# Altium schematic sheet TestingPCB.SchDoc
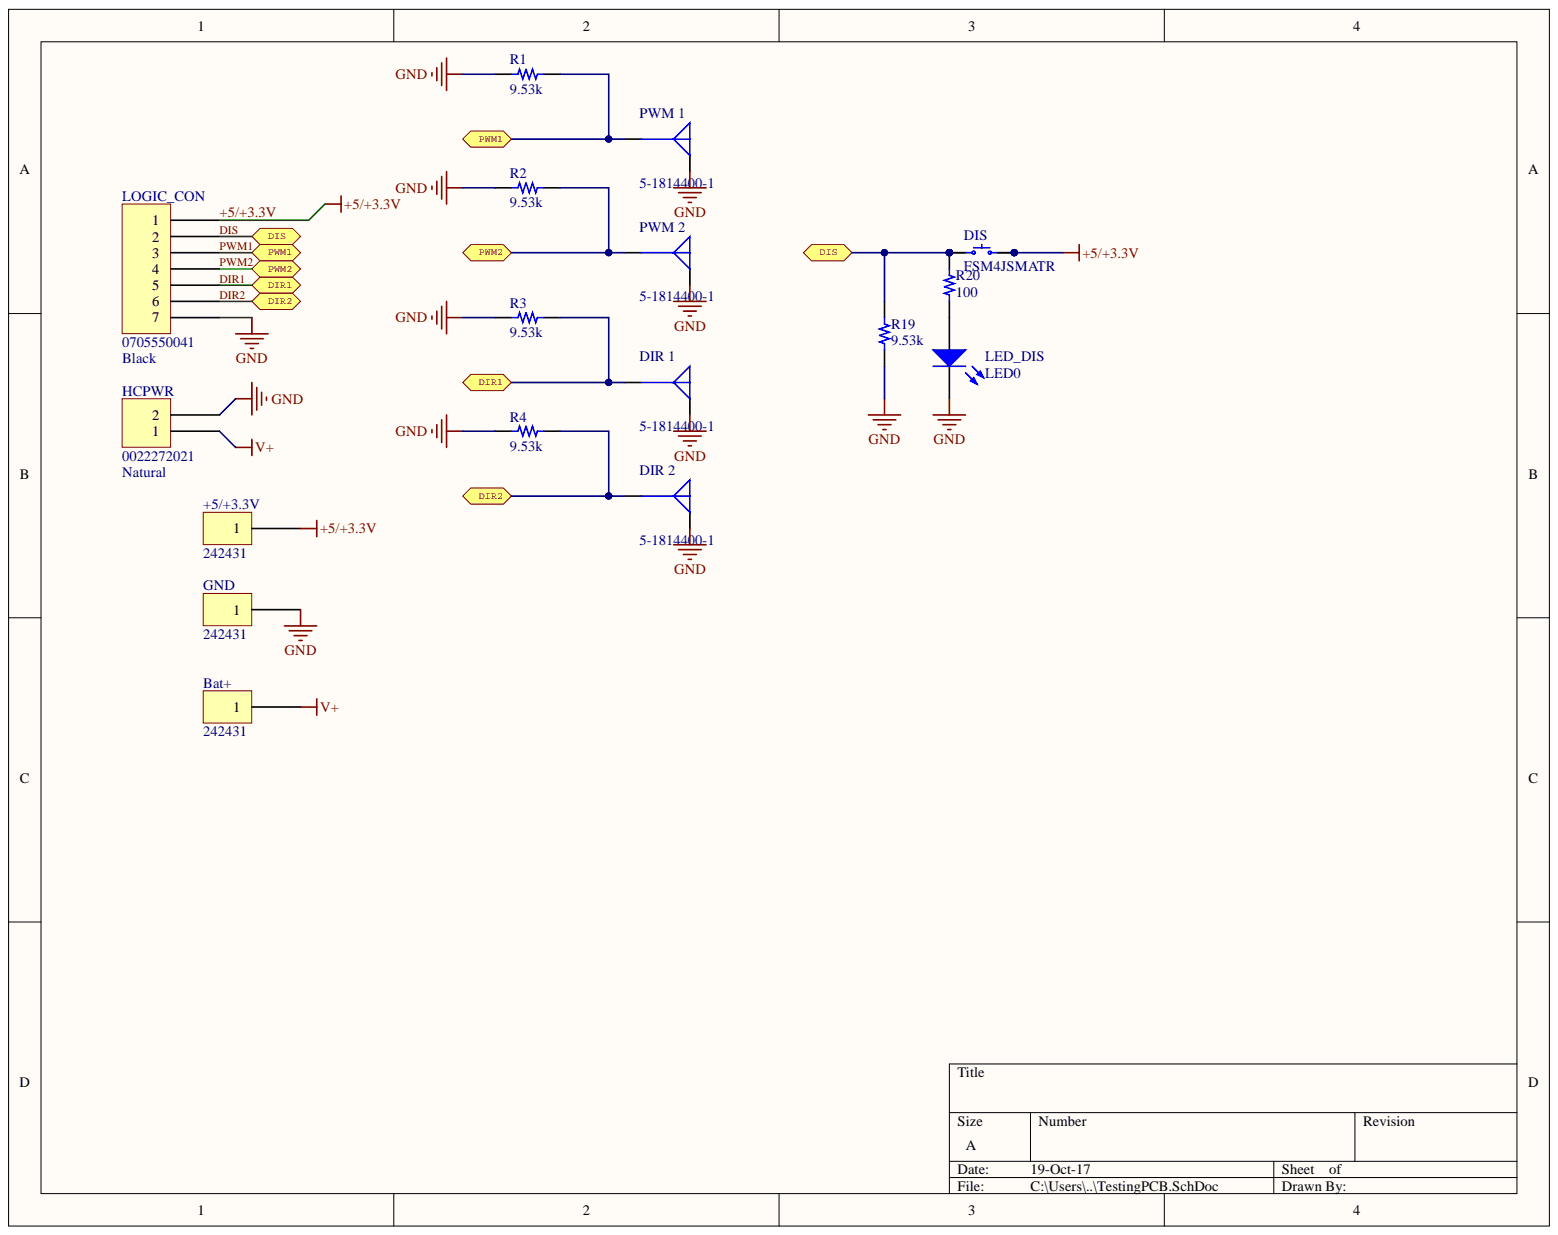
[diagram p0: TestingPCB.SchDoc]

## Parts
+5/+3.3V  242431  [242431 Banana]
Bat+  242431  [242431 Banana]
DIR_1  5-1814400-1  [MOLEX SD-73100-0114]
DIR_2  5-1814400-1  [MOLEX SD-73100-0114]
DIS  FSM4JSMATR  [TE FSM4JSMA]
GND  242431  [242431 Banana]
HCPWR  0022272021  Colour: Natural  [MOLEX KK 0022272021]
LED_DIS  LED0  [LED-0]
LOGIC_CON  0705550041  Colour: Black  [MOLEX SDA-70555-7PIN]
PWM_1  5-1814400-1  [MOLEX SD-73100-0114]
PWM_2  5-1814400-1  [MOLEX SD-73100-0114]
R1  9.53k  [RES 1206/3216]
R2  9.53k  [RES 1206/3216]
R3  9.53k  [RES 1206/3216]
R4  9.53k  [RES 1206/3216]
R19  9.53k  [RES 1206/3216]
R20  100  [RES 1206/3216]

## Ports
DIR1, DIR2, DIS, PWM1, PWM2

## Nets
GND: DIR_1.G DIR_2.G GND.1 HCPWR.2 LED_DIS.2 LOGIC_CON.7 PWM_1.G PWM_2.G R1.1 R2.1 R3.1 R4.1 R19.1
V+: Bat+.1 HCPWR.1
+5/+3.3V: +5/+3.3V.1 DIS.3 DIS.4 LOGIC_CON.1
DIR1: DIR_1.S LOGIC_CON.5 R3.2 ; port DIR1
DIR2: DIR_2.S LOGIC_CON.6 R4.2 ; port DIR2
DIS: DIS.1 DIS.2 LOGIC_CON.2 R19.2 R20.2 ; port DIS
PWM1: LOGIC_CON.3 PWM_1.S R1.2 ; port PWM1
PWM2: LOGIC_CON.4 PWM_2.S R2.2 ; port PWM2
NetLED_DIS_1: LED_DIS.1 R20.1

## Library data of the parts
+5/+3.3V Bat+ GND = 242431: Banana PCB Connector; Manufacturer: Multicomp; Database Table Name: Connector - Terminal Block; Number Of Levels: 1; Voltage: 30V; Component Kind: Standard; ComponentLink1Description: Datasheet; ComponentLink1URL: http://www.farnell.com/datasheets/1901211.pdf?_ga=2.243946508.88394351.1508160428-490126870.1506116288&_gac=1.47786581.1506116288.CjwKCAjw6ZLOBRALEiwAxzyCWz0InfLjFu8mp1t2E94Bo3V2sP4VEUhaMoYFsFDilr1LVY; ComponentLink2Description: Farnell Listing; ComponentLink2URL: http://fr.farnell.com/multicomp/24-243-2/fiche-femelle-ci-4mm-noir/dp/1698983; Library Name: Celestial Library Database.DbLib; PartId: 16448; Part Number: 242431; Positions Per Level: 1; Price: 1.83; Supplier 1: Farnell; Supplier Part Number 1: 1698983
DIR_1 DIR_2 PWM_1 PWM_2 = 5-1814400-1: CONN SMA JACK R/A 50 OHM PCB; Manufacturer: TE Connectivity AMP Connectors; Body Finish: Gold; Body Material: Zinc Alloy; Center Contact Material: Beryllium Copper; Contact Termination: Solder; Database Table Name: Connector - RF; Dielectric Material: Polytetrafluoroethylene (PTFE); Frequency - Max: 3 GHz; Impedance: 50 Ohm; Center Contact Plating: Gold; Component Kind: Standard; ComponentLink1Description: Datasheet; ComponentLink1URL: http://www.farnell.com/datasheets/1803168.pdf?_ga=2.243448460.88394351.1508160428-490126870.1506116288&_gac=1.223996649.1506116288.CjwKCAjw6ZLOBRALEiwAxzyCWz0InfLjFu8mp1t2E94Bo3V2sP4VEUhaMoYFsFDilr1LV; ComponentLink2Description: Farnell Listing; ComponentLink2URL: http://fr.farnell.com/te-connectivity-amp/5-1814400-1/embase-femelle-sma-angle-droit/dp/1248989?ost=5-1814400-1&iscrfnonsku=false&ddkey=http%3Afr-FR%2FElement14_France%2Fsearch; Fastening Type: Threaded; Housing Colour: Gold; Library Name: Celestial Library Database.DbLib; Mounting Type: Through Hole, Right Angle; Operating Temperature: -55°C ~ 85°C; PartId: 16436; Part Number: 5-1814400-1; Price: 1.53; Shield Termination: Solder; Style: SMA; Supplier 1: Farnell; Supplier Part Number 1: 1248989; Type: Jack, Female Socket
DIS = FSM4JSMATR: SWITCH TACTILE SPST-NO 0.05A 24V; Manufacturer: TE Connectivity Alcoswitch Switches; Actuator Orientation: Top Actuated; Actuator Type: Standard; Contact Rating @ Voltage: 0.05A @ 24VDC; Database Table Name: Button - Tactile; Illumination: Non-Illuminated; Mechanical Life: 100,000 Cycles; Operating Force: 160gf; Switch Function: Off-Mom; Switch Travel: 0.25mm; Circuit: SPST-NO; Component Kind: Standard; ComponentLink1Description: Datasheet; ComponentLink1URL: http://www.te.com/commerce/DocumentDelivery/DDEController?Action=srchrtrv&DocNm=2-1437565-7&DocType=Customer+Drawing&DocLang=English; ComponentLink2Description: Digi-Key Listing; ComponentLink2URL: http://www.digikey.com/product-detail/en/FSM4JSMATR/450-1759-2-ND/529675; Illumination Type, Colour: -; Illumination Voltage: -; Library Name: Celestial Library Database.DbLib; Operating Temperature: -35C ~ 85C; PartId: 8249; Part Number: FSM4JSMATR; Price: 0.128; Supplier 1: Digi-Key; Supplier Part Number 1: 450-1759-2-ND
HCPWR = 0022272021: CONN HEADER 2POS .100 VERT TIN; Manufacturer: Molex, LLC; Database Table Name: Connector - Rectangular; Number Of Positions: 2; Pitch: 0.100" (2.54mm); Component Kind: Standard; ComponentLink1Description: Datasheet; ComponentLink1URL: http://www.molex.com/pdm_docs/sd/022272021_sd.pdf; ComponentLink2Description: Digi-Key Listing; ComponentLink2URL: http://www.digikey.com/product-search/en?keywords=22-27-2021; Contact Finish: Tin; Fastening Type: Friction Lock; Library Name: Celestial Library Database.DbLib; Number Of Rows: 1; PartId: 9719; Part Number: 0022272021; Price: 0.25; Series: KK 6410; Supplier 1: Digi-Key; Supplier Part Number 1: WM4111-ND; Type: Header, Unshrouded
LED_DIS = LED0: Typical INFRARED GaAs LED; Component Kind: Standard; LatestRevisionDate: 08-Jul-2005; LatestRevisionNote: Mech Layer 1 removed.; Library Name: Miscellaneous Devices.IntLib; PackageDescription: LED; 2 Leads; PackageReference: LED-0; Published: 8-Jun-2000; Simulation: LED0; Publisher: Altium Limited
LOGIC_CON = 0705550041: CONN HEADER 7POS .100 R/A 15AU; Manufacturer: Molex, LLC; Database Table Name: Connector - Rectangular; Number Of Positions: 7; Pitch: 0.100" (2.54mm); Component Kind: Standard; ComponentLink1Description: Datasheet; ComponentLink1URL: http://www.molex.com/pdm_docs/sd/705550001_sd.pdf; ComponentLink2Description: Digi-Key Listing; ComponentLink2URL: http://www.digikey.ca/product-detail/en/0705550041/WM4180-ND/1643414; Contact Finish: Gold; Fastening Type: -; Library Name: Celestial Library Database.DbLib; Number Of Rows: 1; PartId: 7813; Part Number: 0705550041; Price: 3.99; Series: 70555; Supplier 1: Digi-Key; Supplier Part Number 1: WM4180-ND; Type: Through Hole, Right Angle
R1-R4 R19 = ERJ-8ENF9531V: RES SMD 9.53K OHM 1% 1/4W 1206; Manufacturer: Panasonic Electronic Components; Database Table Name: Resistor - Chip; PowerRating: 0.25W, 1/4W; TemperatureCoefficient: 100ppm/C; TemperatureRange: -55C ~ 155C; Component Kind: Standard; ComponentLink1Description: Datasheet; ComponentLink1URL: http://industrial.panasonic.com/www-cgi/jvcr13pz.cgi?E+PZ+3+AOA0002+ERJ8ENF9531V+7+WW; ComponentLink2Description: Digi-Key listing; ComponentLink2URL: http://digikey.com/product-detail/en/ERJ-8ENF9531V/P9.53KFTR-ND/89055; Device Package: 1206 (3216 Metric); Library Name: Celestial Library Database.DbLib; PartId: 3197; Part Number: ERJ-8ENF9531V; Price: 0.0093; Supplier 1: Digi-Key; Supplier Part Number 1: P9.53KFTR-ND; Tolerance: 1%; Type: Thick Film; Value: 9.53k
R20 = ERA-8AEB101V: RES SMD 100 OHM 0.1% 1/4W 1206; Manufacturer: Panasonic Electronic Components; Database Table Name: Resistor - Chip; PowerRating: 0.25W, 1/4W; TemperatureCoefficient: 25ppm/C; TemperatureRange: -55C ~ 155C; Component Kind: Standard; ComponentLink1Description: Datasheet; ComponentLink1URL: http://industrial.panasonic.com/lecs/www-data/pdf/AOA0000/AOA0000CE26.pdf; ComponentLink2Description: Digi-Key listing; ComponentLink2URL: http://digikey.com/product-detail/en/ERA-8AEB101V/P100BCTR-ND/3070736; Device Package: 1206 (3216 Metric); Library Name: Celestial Library Database.DbLib; PartId: 3388; Part Number: ERA-8AEB101V; Price: 0.1954; Supplier 1: Digi-Key; Supplier Part Number 1: P100BCTR-ND; Tolerance: 0.1%; Type: Thin Film; Value: 100
